# Altium schematic sheet toplevel.SchDoc(toplevel)
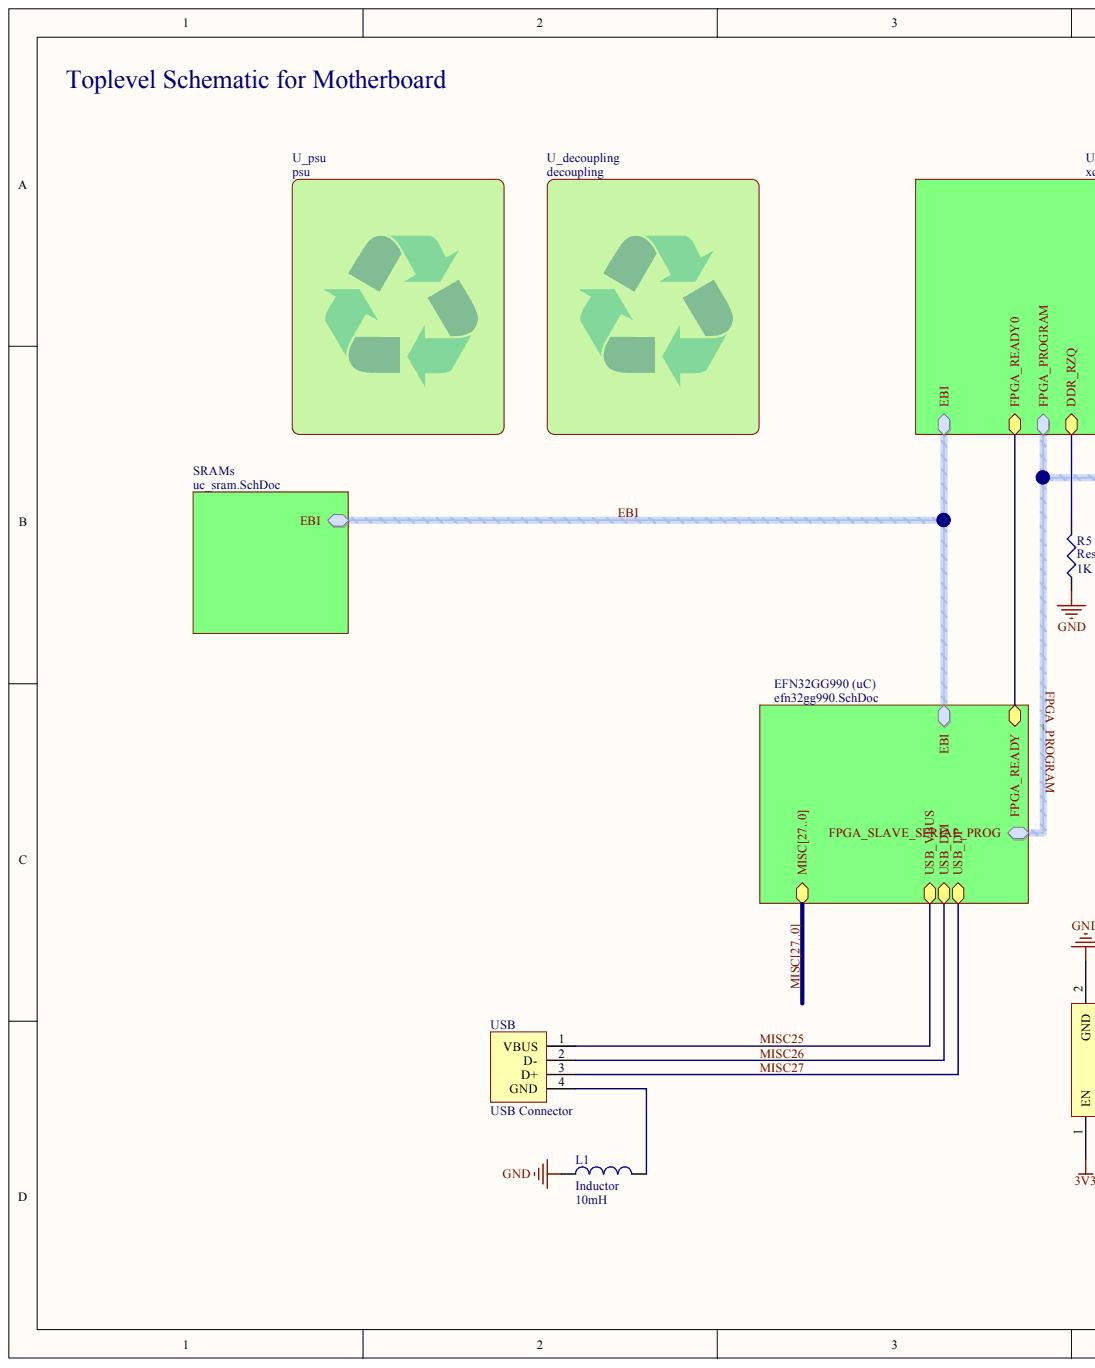
[diagram p0: toplevel.SchDoc, left]
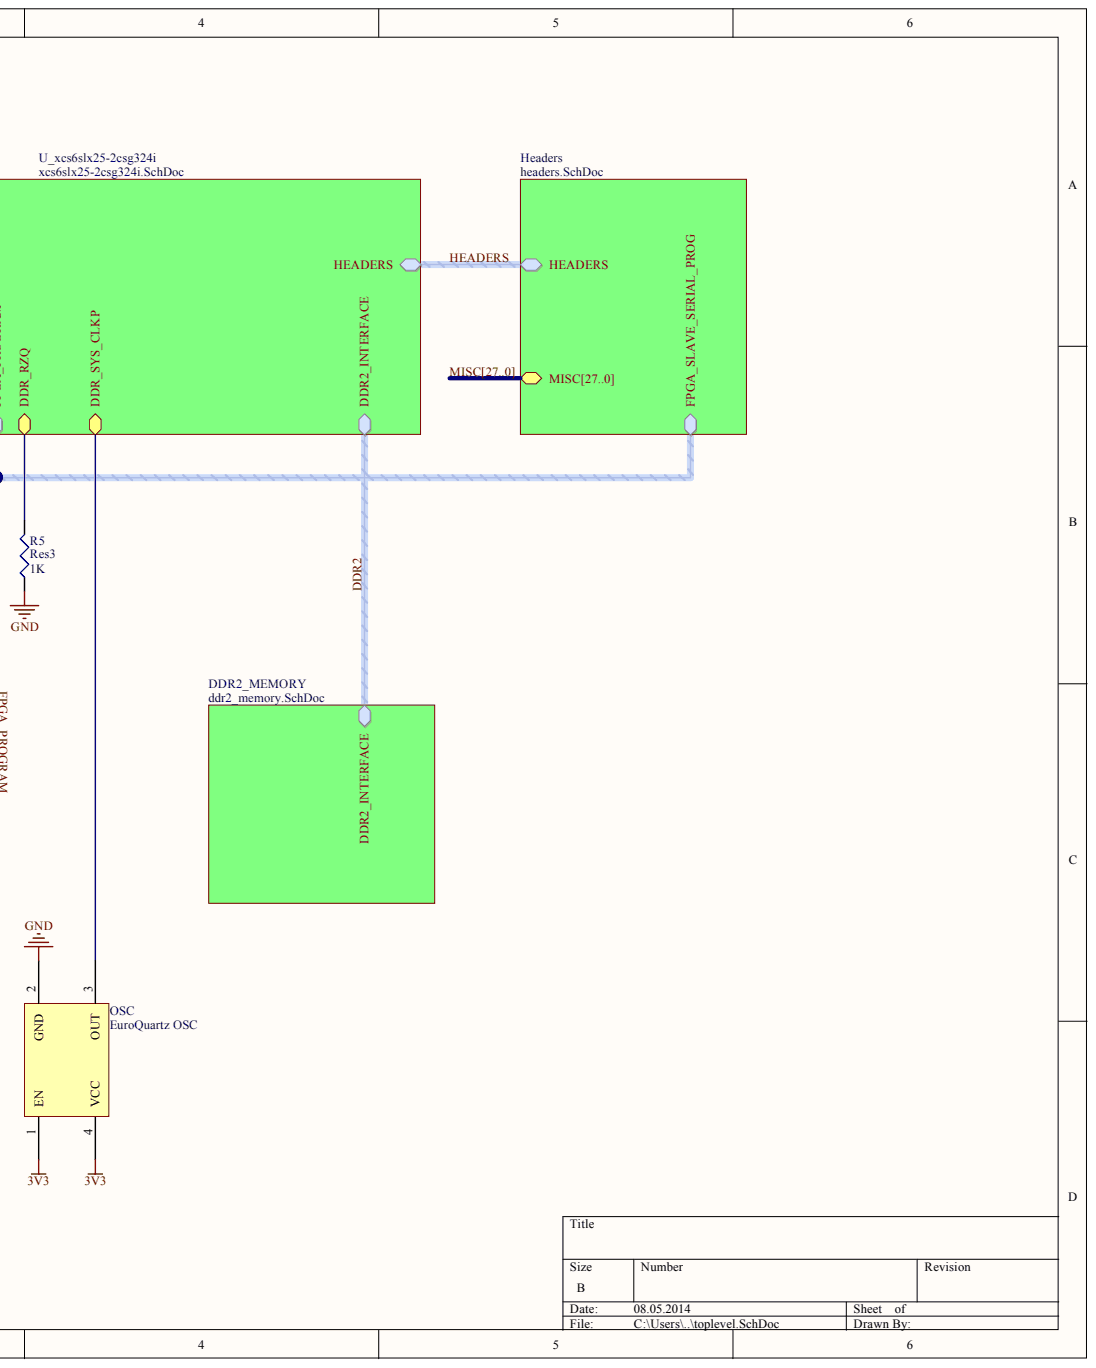
[diagram p1: toplevel.SchDoc, right]

## Parts
L1  Inductor  value 10mH
OSC  EuroQuartz OSC
R5  Res3  value 1K
USB  USB Connector

## Nets
3V3: OSC.1(EN) OSC.4(VCC)
GND: L1.1 OSC.2(GND) R5.1
MISC25: USB.1(VBUS)  [also labelled MISC]
MISC26: USB.2(D-)  [also labelled MISC]
MISC27: USB.3(D+)  [also labelled MISC]
NetL1_2: L1.2 USB.4(GND)
NetOSC_3: OSC.3(OUT)
NetR5_2: R5.2
DDR2.DDR_A0:  [also labelled DDR2.DDR_A]
DDR2.DDR_A1:  [also labelled DDR2.DDR_A]
DDR2.DDR_A2:  [also labelled DDR2.DDR_A]
DDR2.DDR_A3:  [also labelled DDR2.DDR_A]
DDR2.DDR_A4:  [also labelled DDR2.DDR_A]
DDR2.DDR_A5:  [also labelled DDR2.DDR_A]
DDR2.DDR_A6:  [also labelled DDR2.DDR_A]
DDR2.DDR_A7:  [also labelled DDR2.DDR_A]
DDR2.DDR_A8:  [also labelled DDR2.DDR_A]
DDR2.DDR_A9:  [also labelled DDR2.DDR_A]
DDR2.DDR_A10:  [also labelled DDR2.DDR_A]
DDR2.DDR_A11:  [also labelled DDR2.DDR_A]
DDR2.DDR_A12:  [also labelled DDR2.DDR_A]
DDR2.DDR_A13:  [also labelled DDR2.DDR_A]
DDR2.DDR_BA0:
DDR2.DDR_BA1:
DDR2.DDR_BA2:
DDR2.DDR_CASN:
DDR2.DDR_CLK_N:
DDR2.DDR_CLK_P:
DDR2.DDR_CLKE:
DDR2.DDR_DQ0:  [also labelled DDR2.DDR_DQ]
DDR2.DDR_DQ1:  [also labelled DDR2.DDR_DQ]
DDR2.DDR_DQ2:  [also labelled DDR2.DDR_DQ]
DDR2.DDR_DQ3:  [also labelled DDR2.DDR_DQ]
DDR2.DDR_DQ4:  [also labelled DDR2.DDR_DQ]
DDR2.DDR_DQ5:  [also labelled DDR2.DDR_DQ]
DDR2.DDR_DQ6:  [also labelled DDR2.DDR_DQ]
DDR2.DDR_DQ7:  [also labelled DDR2.DDR_DQ]
DDR2.DDR_DQS_N:
DDR2.DDR_DQS_P:
DDR2.DDR_LDM:
DDR2.DDR_ODT:
DDR2.DDR_RASN:
DDR2.DDR_WE:
EBI.ADDR0:  [also labelled EBI.ADDR]
EBI.ADDR1:  [also labelled EBI.ADDR]
EBI.ADDR2:  [also labelled EBI.ADDR]
EBI.ADDR3:  [also labelled EBI.ADDR]
EBI.ADDR4:  [also labelled EBI.ADDR]
EBI.ADDR5:  [also labelled EBI.ADDR]
EBI.ADDR6:  [also labelled EBI.ADDR]
EBI.ADDR7:  [also labelled EBI.ADDR]
EBI.ADDR8:  [also labelled EBI.ADDR]
EBI.ADDR9:  [also labelled EBI.ADDR]
EBI.ADDR10:  [also labelled EBI.ADDR]
EBI.ADDR11:  [also labelled EBI.ADDR]
EBI.ADDR12:  [also labelled EBI.ADDR]
EBI.ADDR13:  [also labelled EBI.ADDR]
EBI.ADDR14:  [also labelled EBI.ADDR]
EBI.ADDR15:  [also labelled EBI.ADDR]
EBI.ADDR16:  [also labelled EBI.ADDR]
EBI.ADDR17:  [also labelled EBI.ADDR]
EBI.ADDR18:  [also labelled EBI.ADDR]
EBI.ADDR19:  [also labelled EBI.ADDR]
EBI.ADDR20:  [also labelled EBI.ADDR]
EBI.DATA0:  [also labelled EBI.DATA]
EBI.DATA1:  [also labelled EBI.DATA]
EBI.DATA2:  [also labelled EBI.DATA]
EBI.DATA3:  [also labelled EBI.DATA]
EBI.DATA4:  [also labelled EBI.DATA]
EBI.DATA5:  [also labelled EBI.DATA]
EBI.DATA6:  [also labelled EBI.DATA]
EBI.DATA7:  [also labelled EBI.DATA]
EBI.DATA8:  [also labelled EBI.DATA]
EBI.DATA9:  [also labelled EBI.DATA]
EBI.DATA10:  [also labelled EBI.DATA]
EBI.DATA11:  [also labelled EBI.DATA]
EBI.DATA12:  [also labelled EBI.DATA]
EBI.DATA13:  [also labelled EBI.DATA]
EBI.DATA14:  [also labelled EBI.DATA]
EBI.DATA15:  [also labelled EBI.DATA]
EBI.READ:
EBI.SELECT0:
EBI.SELECT1:
EBI.SELECT2:
EBI.SELECT3:
EBI.WRITE:
FPGA_PROGRAM.CCLK:
FPGA_PROGRAM.DATA:
FPGA_PROGRAM.DONE:
FPGA_PROGRAM.INIT_B:
FPGA_PROGRAM.PROGRAM_B:
FPGA_READY:
HEADERS.HE0:  [also labelled HEADERS.HE]
HEADERS.HE1:  [also labelled HEADERS.HE]
HEADERS.HE2:  [also labelled HEADERS.HE]
HEADERS.HE3:  [also labelled HEADERS.HE]
HEADERS.HE4:  [also labelled HEADERS.HE]
HEADERS.HE5:  [also labelled HEADERS.HE]
HEADERS.HE6:  [also labelled HEADERS.HE]
HEADERS.HE7:  [also labelled HEADERS.HE]
HEADERS.HE8:  [also labelled HEADERS.HE]
HEADERS.HE9:  [also labelled HEADERS.HE]
HEADERS.HE10:  [also labelled HEADERS.HE]
HEADERS.HE11:  [also labelled HEADERS.HE]
HEADERS.HE12:  [also labelled HEADERS.HE]
HEADERS.HE13:  [also labelled HEADERS.HE]
HEADERS.HE14:  [also labelled HEADERS.HE]
HEADERS.HE15:  [also labelled HEADERS.HE]
HEADERS.HE16:  [also labelled HEADERS.HE]
HEADERS.HE17:  [also labelled HEADERS.HE]
HEADERS.HE18:  [also labelled HEADERS.HE]
HEADERS.HE19:  [also labelled HEADERS.HE]
HEADERS.HE20:  [also labelled HEADERS.HE]
HEADERS.HE21:  [also labelled HEADERS.HE]
HEADERS.HE22:  [also labelled HEADERS.HE]
HEADERS.HE23:  [also labelled HEADERS.HE]
HEADERS.HE24:  [also labelled HEADERS.HE]
HEADERS.HN0:  [also labelled HEADERS.HN]
HEADERS.HN1:  [also labelled HEADERS.HN]
HEADERS.HN2:  [also labelled HEADERS.HN]
HEADERS.HN3:  [also labelled HEADERS.HN]
HEADERS.HN4:  [also labelled HEADERS.HN]
HEADERS.HN5:  [also labelled HEADERS.HN]
HEADERS.HN6:  [also labelled HEADERS.HN]
HEADERS.HN7:  [also labelled HEADERS.HN]
HEADERS.HN8:  [also labelled HEADERS.HN]
HEADERS.HN9:  [also labelled HEADERS.HN]
HEADERS.HN10:  [also labelled HEADERS.HN]
HEADERS.HN11:  [also labelled HEADERS.HN]
HEADERS.HN12:  [also labelled HEADERS.HN]
HEADERS.HN13:  [also labelled HEADERS.HN]
HEADERS.HN14:  [also labelled HEADERS.HN]
HEADERS.HN15:  [also labelled HEADERS.HN]
HEADERS.HN16:  [also labelled HEADERS.HN]
HEADERS.HN17:  [also labelled HEADERS.HN]
HEADERS.HN18:  [also labelled HEADERS.HN]
HEADERS.HN19:  [also labelled HEADERS.HN]
HEADERS.HN20:  [also labelled HEADERS.HN]
HEADERS.HN21:  [also labelled HEADERS.HN]
HEADERS.HN22:  [also labelled HEADERS.HN]
HEADERS.HN23:  [also labelled HEADERS.HN]
HEADERS.HN24:  [also labelled HEADERS.HN]
HEADERS.HN25:  [also labelled HEADERS.HN]
HEADERS.HN26:  [also labelled HEADERS.HN]
HEADERS.HN27:  [also labelled HEADERS.HN]
HEADERS.HN28:  [also labelled HEADERS.HN]
HEADERS.HN29:  [also labelled HEADERS.HN]
HEADERS.HN30:  [also labelled HEADERS.HN]
HEADERS.HN31:  [also labelled HEADERS.HN]
HEADERS.HN32:  [also labelled HEADERS.HN]
HEADERS.HN33:  [also labelled HEADERS.HN]
HEADERS.HN34:  [also labelled HEADERS.HN]
HEADERS.HN35:  [also labelled HEADERS.HN]
HEADERS.HN36:  [also labelled HEADERS.HN]
HEADERS.HN37:  [also labelled HEADERS.HN]
HEADERS.HN38:  [also labelled HEADERS.HN]
HEADERS.HN39:  [also labelled HEADERS.HN]
HEADERS.HN40:  [also labelled HEADERS.HN]
HEADERS.HN41:  [also labelled HEADERS.HN]
HEADERS.HN42:  [also labelled HEADERS.HN]
HEADERS.HN43:  [also labelled HEADERS.HN]
HEADERS.HN44:  [also labelled HEADERS.HN]
HEADERS.HN45:  [also labelled HEADERS.HN]
HEADERS.HN46:  [also labelled HEADERS.HN]
HEADERS.HN47:  [also labelled HEADERS.HN]
HEADERS.HN48:  [also labelled HEADERS.HN]
HEADERS.HN49:  [also labelled HEADERS.HN]
HEADERS.HN50:  [also labelled HEADERS.HN]
HEADERS.HN51:  [also labelled HEADERS.HN]
HEADERS.HN52:  [also labelled HEADERS.HN]
HEADERS.HN53:  [also labelled HEADERS.HN]
HEADERS.HN54:  [also labelled HEADERS.HN]
HEADERS.HN55:  [also labelled HEADERS.HN]
HEADERS.HW0:  [also labelled HEADERS.HW]
HEADERS.HW1:  [also labelled HEADERS.HW]
HEADERS.HW2:  [also labelled HEADERS.HW]
HEADERS.HW3:  [also labelled HEADERS.HW]
HEADERS.HW4:  [also labelled HEADERS.HW]
HEADERS.HW5:  [also labelled HEADERS.HW]
HEADERS.HW6:  [also labelled HEADERS.HW]
HEADERS.HW7:  [also labelled HEADERS.HW]
HEADERS.HW8:  [also labelled HEADERS.HW]
HEADERS.HW9:  [also labelled HEADERS.HW]
HEADERS.HW10:  [also labelled HEADERS.HW]
HEADERS.HW11:  [also labelled HEADERS.HW]
HEADERS.HW12:  [also labelled HEADERS.HW]
HEADERS.HW13:  [also labelled HEADERS.HW]
HEADERS.HW14:  [also labelled HEADERS.HW]
HEADERS.HW15:  [also labelled HEADERS.HW]
HEADERS.HW16:  [also labelled HEADERS.HW]
HEADERS.HW17:  [also labelled HEADERS.HW]
HEADERS.HW18:  [also labelled HEADERS.HW]
HEADERS.HW19:  [also labelled HEADERS.HW]
HEADERS.HW20:  [also labelled HEADERS.HW]
HEADERS.HW21:  [also labelled HEADERS.HW]
HEADERS.HW22:  [also labelled HEADERS.HW]
HEADERS.HW23:  [also labelled HEADERS.HW]
HEADERS.HW24:  [also labelled HEADERS.HW]
HEADERS.HW25:  [also labelled HEADERS.HW]
HEADERS.HW26:  [also labelled HEADERS.HW]
HEADERS.HW27:  [also labelled HEADERS.HW]
HEADERS.HW28:  [also labelled HEADERS.HW]
HEADERS.HW29:  [also labelled HEADERS.HW]
HEADERS.HW30:  [also labelled HEADERS.HW]
HEADERS.HW31:  [also labelled HEADERS.HW]
HEADERS.HW32:  [also labelled HEADERS.HW]
HEADERS.HW33:  [also labelled HEADERS.HW]
HEADERS.HW34:  [also labelled HEADERS.HW]
HEADERS.HW35:  [also labelled HEADERS.HW]
HEADERS.HW36:  [also labelled HEADERS.HW]
HEADERS.HW37:  [also labelled HEADERS.HW]
HEADERS.HW38:  [also labelled HEADERS.HW]
HEADERS.HW39:  [also labelled HEADERS.HW]
HEADERS.HW40:  [also labelled HEADERS.HW]
HEADERS.HW41:  [also labelled HEADERS.HW]
HEADERS.HW42:  [also labelled HEADERS.HW]
HEADERS.HW43:  [also labelled HEADERS.HW]
HEADERS.HW44:  [also labelled HEADERS.HW]
HEADERS.HW45:  [also labelled HEADERS.HW]
HEADERS.HW46:  [also labelled HEADERS.HW]
HEADERS.HW47:  [also labelled HEADERS.HW]
HEADERS.HW48:  [also labelled HEADERS.HW]
HEADERS.HW49:  [also labelled HEADERS.HW]
HEADERS.HW50:  [also labelled HEADERS.HW]
HEADERS.HW51:  [also labelled HEADERS.HW]
HEADERS.HW52:  [also labelled HEADERS.HW]
MISC0:  [also labelled MISC]
MISC1:  [also labelled MISC]
MISC2:  [also labelled MISC]
MISC3:  [also labelled MISC]
MISC4:  [also labelled MISC]
MISC5:  [also labelled MISC]
MISC6:  [also labelled MISC]
MISC7:  [also labelled MISC]
MISC8:  [also labelled MISC]
MISC9:  [also labelled MISC]
MISC10:  [also labelled MISC]
MISC11:  [also labelled MISC]
MISC12:  [also labelled MISC]
MISC13:  [also labelled MISC]
MISC14:  [also labelled MISC]
MISC15:  [also labelled MISC]
MISC16:  [also labelled MISC]
MISC17:  [also labelled MISC]
MISC18:  [also labelled MISC]
MISC19:  [also labelled MISC]
MISC20:  [also labelled MISC]
MISC21:  [also labelled MISC]
MISC22:  [also labelled MISC]
MISC23:  [also labelled MISC]
MISC24:  [also labelled MISC]

## Library data of the parts
L1 = (no symbol name): Code_IEC: 1005; Published: 8-Jun-2000; LatestRevisionDate: 29-May-2009; LatestRevisionNote: IPC-7351 Footprint Added.; PackageReference: 0402-A; PackageDescription: Chip Inductor; Code_JEITA: 0402; Publisher: Altium Limited
OSC R5 USB = (no symbol name)
  R5: Published: 8-Jun-2000; LatestRevisionDate: 29-May-2009; LatestRevisionNote: IPC-7351 Footprint Added.; PackageReference: J1-0603; PackageDescription: Chip Resistor; Publisher: Altium Limited
